# Altium schematic sheet STM32_AVR_demoboard_2.SchDoc(STM32_AVR_demoboard_2)
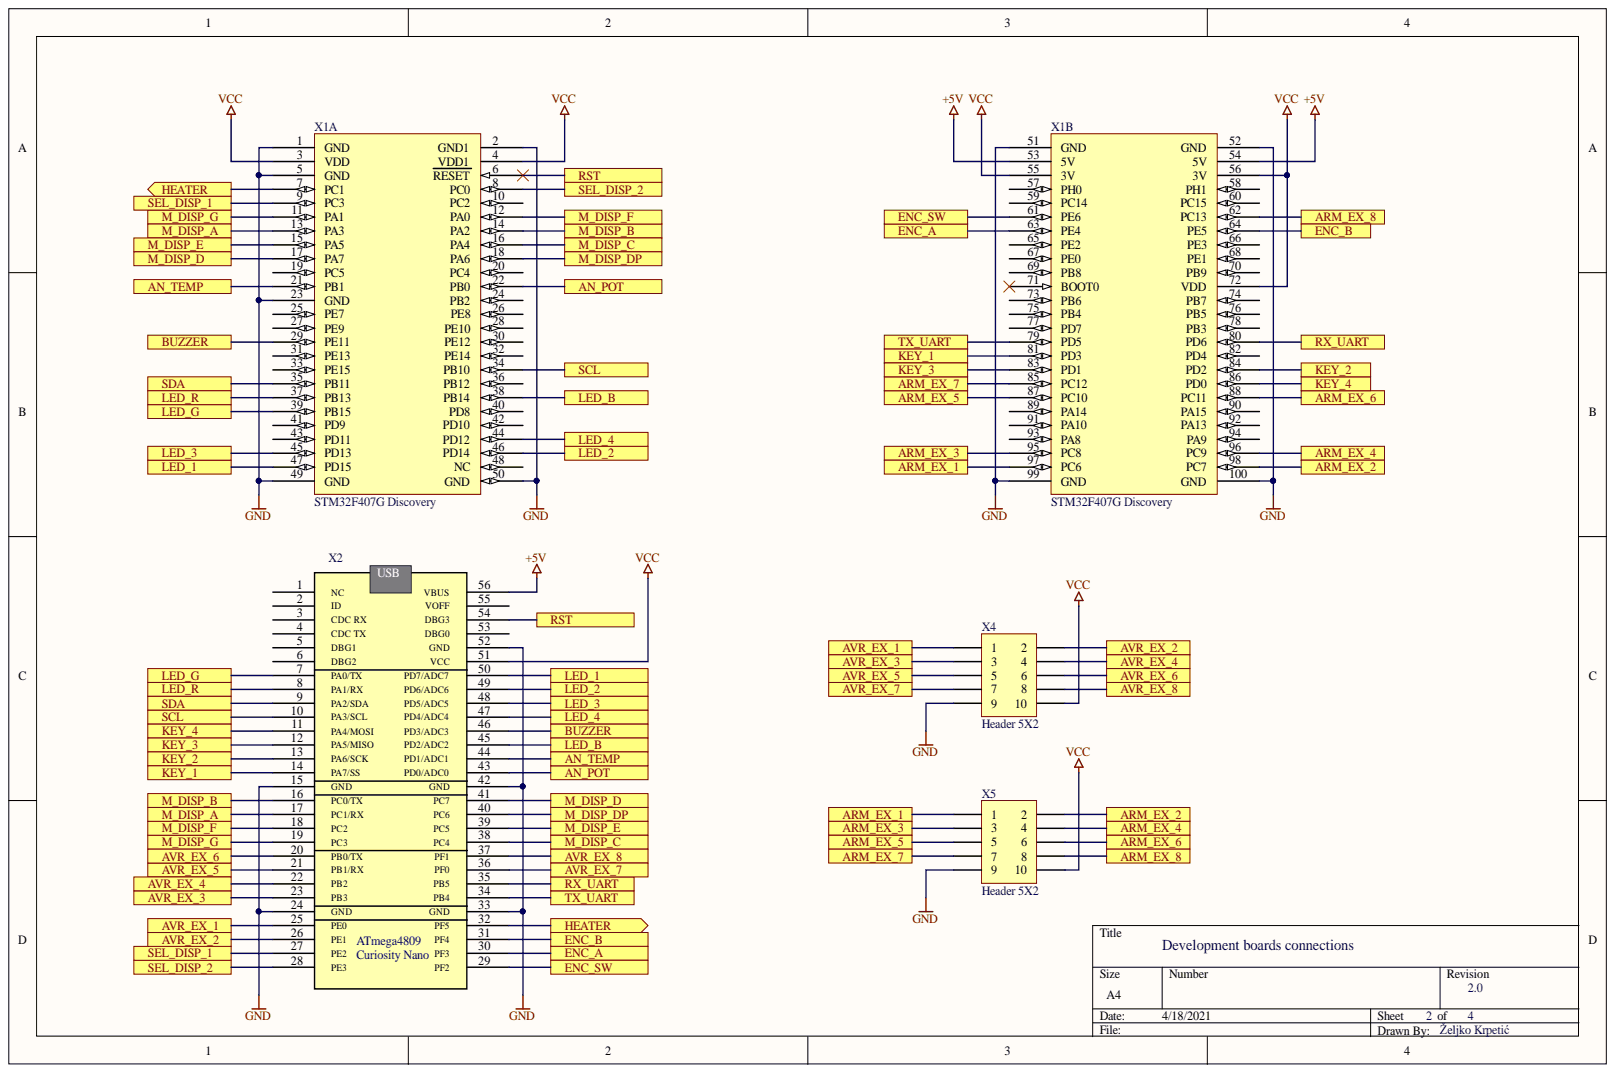
[diagram p0: STM32_AVR_demoboard_2.SchDoc]

## Parts
X1  STM32F407G Discovery  [STM32F407G-DISC1]  (parts A,B)
X2  Atmega4809-CNANO  (value not drawn)
X4  Header 5X2  [HDR2X5]
X5  Header 5X2  [HDR2X5]

## Ports
AN_POT, AN_TEMP, ARM_EX_1, ARM_EX_2, ARM_EX_3, ARM_EX_4, ARM_EX_5, ARM_EX_6, ARM_EX_7, ARM_EX_8, AVR_EX_1, AVR_EX_2, AVR_EX_3, AVR_EX_4, AVR_EX_5, AVR_EX_6, AVR_EX_7, AVR_EX_8, BUZZER, ENC_A, ENC_B, ENC_SW, HEATER, KEY_1, KEY_2, KEY_3, KEY_4, LED_1, LED_2, LED_3, LED_4, LED_B, LED_G, LED_R, M_DISP_A, M_DISP_B, M_DISP_C, M_DISP_D, M_DISP_DP, M_DISP_E, M_DISP_F, M_DISP_G, RST, RX_UART, SCL, SDA, SEL_DISP_1, SEL_DISP_2, TX_UART

## Nets
+5V: X1.53 X1.54 X2.56
GND: X1.1 X1.2 X1.5 X1.23 X1.49 X1.50 X1.51 X1.52 X1.99 X1.100 X2.15 X2.24 X2.33 X2.42 X2.52 X4.9 X5.9
VCC: X1.3 X1.4 X1.55 X1.56 X1.72 X2.51 X4.10 X5.10
AN_POT: X1.22 X2.43 ; port AN_POT
AN_TEMP: X1.21 X2.44 ; port AN_TEMP
ARM_EX_1: X1.97 X5.1 ; port ARM_EX_1
ARM_EX_2: X1.98 X5.2 ; port ARM_EX_2
ARM_EX_3: X1.95 X5.3 ; port ARM_EX_3
ARM_EX_4: X1.96 X5.4 ; port ARM_EX_4
ARM_EX_5: X1.87 X5.5 ; port ARM_EX_5
ARM_EX_6: X1.88 X5.6 ; port ARM_EX_6
ARM_EX_7: X1.85 X5.7 ; port ARM_EX_7
ARM_EX_8: X1.62 X5.8 ; port ARM_EX_8
AVR_EX_1: X2.25 X4.1 ; port AVR_EX_1
AVR_EX_2: X2.26 X4.2 ; port AVR_EX_2
AVR_EX_3: X2.23 X4.3 ; port AVR_EX_3
AVR_EX_4: X2.22 X4.4 ; port AVR_EX_4
AVR_EX_5: X2.21 X4.5 ; port AVR_EX_5
AVR_EX_6: X2.20 X4.6 ; port AVR_EX_6
AVR_EX_7: X2.36 X4.7 ; port AVR_EX_7
AVR_EX_8: X2.37 X4.8 ; port AVR_EX_8
BUZZER: X1.29 X2.46 ; port BUZZER
ENC_A: X1.63 X2.30 ; port ENC_A
ENC_B: X1.64 X2.31 ; port ENC_B
ENC_SW: X1.61 X2.29 ; port ENC_SW
HEATER: X1.7 X2.32 ; port HEATER
KEY_1: X1.81 X2.14 ; port KEY_1
KEY_2: X1.84 X2.13 ; port KEY_2
KEY_3: X1.83 X2.12 ; port KEY_3
KEY_4: X1.86 X2.11 ; port KEY_4
LED_1: X1.47 X2.50 ; port LED_1
LED_2: X1.46 X2.49 ; port LED_2
LED_3: X1.45 X2.48 ; port LED_3
LED_4: X1.44 X2.47 ; port LED_4
LED_B: X1.38 X2.45 ; port LED_B
LED_G: X1.39 X2.7 ; port LED_G
LED_R: X1.37 X2.8 ; port LED_R
M_DISP_A: X1.13 X2.17 ; port M_DISP_A
M_DISP_B: X1.14 X2.16 ; port M_DISP_B
M_DISP_C: X1.16 X2.38 ; port M_DISP_C
M_DISP_D: X1.17 X2.41 ; port M_DISP_D
M_DISP_DP: X1.18 X2.40 ; port M_DISP_DP
M_DISP_E: X1.15 X2.39 ; port M_DISP_E
M_DISP_F: X1.12 X2.18 ; port M_DISP_F
M_DISP_G: X1.11 X2.19 ; port M_DISP_G
RST: X1.6 X2.54 ; port RST
RX_UART: X1.80 X2.35 ; port RX_UART
SCL: X1.34 X2.10 ; port SCL
SDA: X1.35 X2.9 ; port SDA
SEL_DISP_1: X1.9 X2.27 ; port SEL_DISP_1
SEL_DISP_2: X1.8 X2.28 ; port SEL_DISP_2
TX_UART: X1.79 X2.34 ; port TX_UART

Not connected: X1 10 19 20 24 25 26 27 28 30 31 32 33 36 40 41 42 43 48 57 58 59 60 65 66 67 68 69 70 71 73 74 75 76 77 78 82 89 90 91 92 93 94; X2 1 2 3 4 5 6 53 55

## Library data of the parts
X1 = STM32F407 Discovery konektor: Component Kind: Standard; Library Name: FER projekt PCB rev2.SCHLIB
X2 = Atmega4809-CNANO: ATmega4809 curiosity nano; Component Kind: Standard; Library Name: FER projekt schLib.SchLib
X4 X5 = Header 5X2: Header, 5-Pin, Dual row; Signal Integrity: Connector; Library Name: Miscellaneous Connectors.IntLib; LatestRevisionNote: Re-released for DXP Platform.; LatestRevisionDate: 17-Jul-2002; Component Kind: Standard; Publisher: Altium Limited
